# Altium schematic sheet 03_CAN.SchDoc
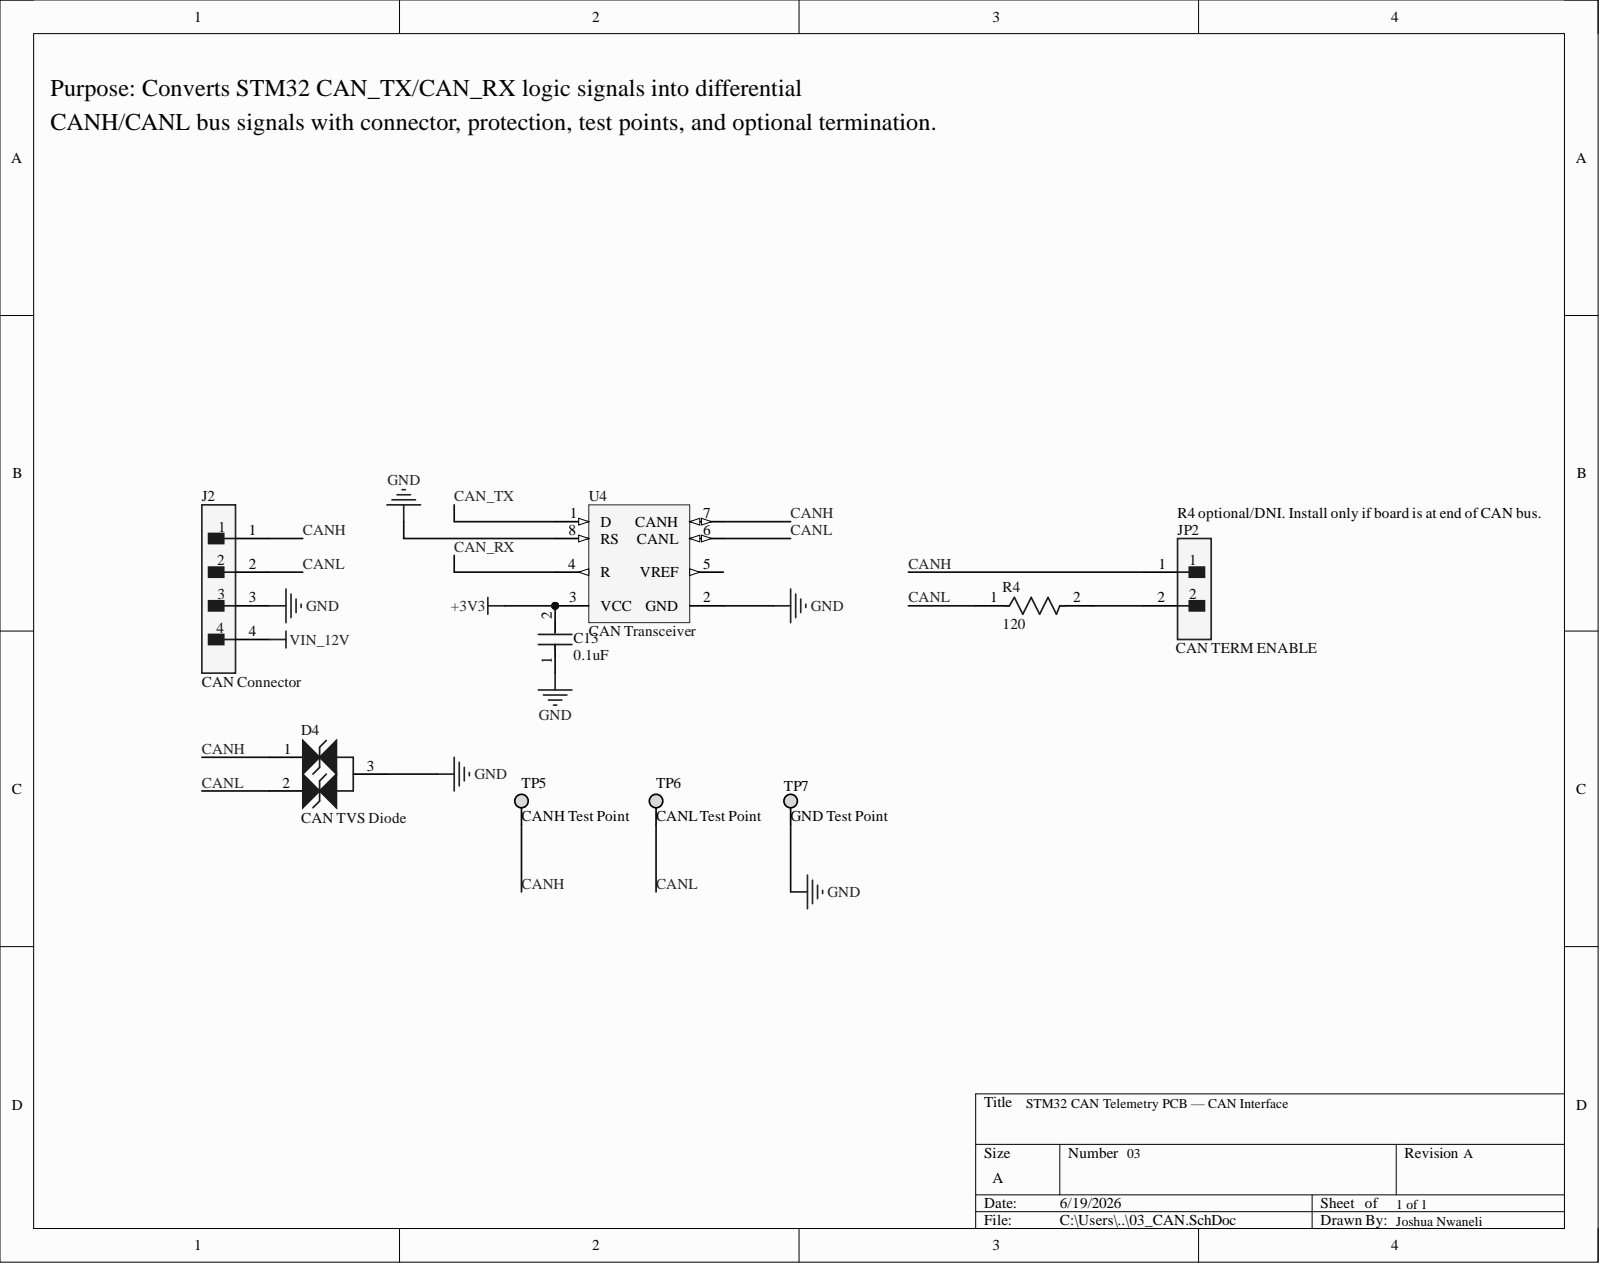
[diagram p0: 03_CAN.SchDoc]

## Parts
C13  0.1uF  [FP-CC0805-EB-MFG]
D4  CAN TVS Diode  [ONSC-SOT-23-3-318-08_V]
J2  CAN Connector  [FP-1777099-MFG]
JP2  CAN TERM ENABLE  [FP-TSW-102-12-T-S-MFG]
R4  120  [FP-RT0805-MFG]
TP5  CANH Test Point  [KSTN-5014_V]
TP6  CANL Test Point  [KSTN-5014_V]
TP7  GND Test Point  [KSTN-5014_V]
U4  CAN Transceiver  [D0008A_M]

## Nets
+3V3: C13.2 U4.3(VCC)
GND: C13.1 D4.3 J2.3 TP7.1 U4.2(GND) U4.8(RS)
VIN_12V: J2.4
CAN_RX: U4.4(R)
CAN_TX: U4.1(D)
CANH: D4.1 J2.1 JP2.1 TP5.1 U4.7(CANH)
CANL: D4.2 J2.2 R4.1 TP6.1 U4.6(CANL)
NetJP2_2: JP2.2 R4.2

Not connected: U4 5(VREF)

## Library data of the parts
C13 = CMP-00621-107850848-1: CC0805KKX7RYBB104 Capacitor Ceramic 0.01uF 250V X7R 10% 0805 T/R; Capacitance: 100nF; Case/Package: 0805; Component Kind: Standard; Height: 1.45mm; Library Name: Altium Content Vault; Material: Ceramic; Max Operating Temperature: 125°C; Min Operating Temperature: -55°C; NexarPartId: 107850848; Tolerance: 10%; Voltage Rating: 250V
D4 = CMP-1063-00169-1: Dual-line ESD/transient protection for CANH and CANL; Manufacturer: On Semiconductor; PartNumber: SZNUP2105LT3G; C Max (pF): 30; Direction: Bidirectional; IR Max (uA): 0.1; Number of Lines: 2; Packing: Tape and Reel; PPK Max (W): 350; V(BR) Min (V): 26.2; Component Kind: Standard; ComponentLink1Description: Manufacturer URL; ComponentLink1URL: http://www.onsemi.com/; ComponentLink2Description: Datasheet; ComponentLink2URL: http://www.onsemi.cn/pub_link/Collateral/NUP2105L-D.PDF; ComponentLink3Description: Package Specification; ComponentLink3URL: http://www.onsemi.cn/pub_link/Collateral/318-08.PDF; DatasheetVersion: Rev. 5; Library Name: Altium Content Vault; Mounting Technology: Surface Mount; PackageDescription: 3-Pin Small Outline Transistor, Body 2.92 x 1.3 mm, Pitch 0.95 mm; PackageReference: SOT-23-3-318-08; PackageVersion: Rev. AP, 11/2009; RoHS: Pb-Free
J2 = CMP-09399-000012-1: TERM BLOCK HDR 4POS VERT 5.08MM; Connector Type: Plug; Current: 12A; Number of Contacts: 4; Number of Levels: 1; Voltage: 300V; Voltage Rating: 250V; Color: Green; Component Kind: Standard; Contact Material: Tin; Contact Plating: Tin; Current Rating: 12A; Depth: 8.3mm; Flammability Rating: UL94 V-0; Height: 15.9mm; Housing Color: Green; Housing Material: Polybutylene; Lead Free: Lead Free; Length: 30.48mm; Library Name: Altium Content Vault; Max Current Rating: 12A; Mount: Through Hole; Number of Positions: 4; Number of Positions Per Level: 4; Number of Rows: 1; Orientation: Straight; Package Quantity: 50; Packaging: Bulk; Pitch: 5.08mm; Series: MSTBV; Termination: Solder; Weight: 5.467464oz; Width: 30.48mm
JP2 = CMP-02766-001444-1: Jumper to enable optional 120R CAN bus termination; Gender: Male; Number of Contacts: 2; Pitch: 2.54mm; Component Kind: Standard; Contact Material: Bronze; Contact Plating: Tin; Library Name: Altium Content Vault; Mount: Through Hole; Number of Rows: 1; Packaging: Bulk; Radiation Hardening: No; REACH SVHC: No SVHC; RoHS Compliant: Yes; Termination: Solder
R4 = CMP-03412-026588-1: RES SMD 120 OHM 0.5% 1/8W 0805; Manufacturer: Yageo; Manufacturer Part Number: RT0805DRD07120RL; Power Rating: 0.125W; Resistance: 120Ω; Temperature Coefficient: 25 ppm/°C; Tolerance: 0.01; Value: 120Ω; Voltage Rating: 150V; Automotive: No; Category: Res; Component Kind: Standard; ComponentLink2Description: Datasheet; ComponentLink2URL: http://www.yageo.com/documents/recent/PYu-RT_1-to-0.01_RoHS_L_9.pdf; Composition: Thin Film; Device Class L1: Passive Components; Device Class L2: Resistors; Device Class L3: Chip SMD Resistors; Digikey Description: RES SMD 120 OHM 0.5% 1/8W 0805; Footprint Url: http://www.yageo.com/exep/pages/download/literatures/PYu-R_Mount_10.pdf; Height: 0.6mm; Ipc Land Pattern Name: RESC200125X50; Lead Free: Yes; Library Name: Altium Content Vault; Octopart: https://octopart.com/rt0805drd07120rl-yageo-1204488; Package: RT0805; RoHS: TRUE; Series: RT; Temperature: -55°C to +155°C; Temperature Range High: +155°C; Temperature Range Low: -55°C
TP5-TP7 = CMP-1672-00006-4: Test Point, Yellow, Through Hole, RoHS, Bulk; Manufacturer: Keystone; Colour: Yellow; Component Kind: Standard; ComponentLink1Description: Manufacturer URL; ComponentLink1URL: http://www.keyelco.com/; ComponentLink2Description: Datasheet; ComponentLink2URL: http://www.keyelco.com/product-pdf.cfm?p=1323; Contact Material: Bronze; Contact Plating: Silver; DatasheetVersion: Rev. F, 12/2013; Height: 8.12 mm; Library Name: Altium Content Vault; Mount: Through Hole; Mounting Technology: Through Hole; Package Quantity: 100; PackageDescription: 1-Pin Through Hole Device, Dia 3.17 mm; PackageReference: 5014; Packaging: Bulk; REACH SVHC: No SVHC; RoHSCompliant: true
U4 = CMP-0315-00046-3: CAN Bus Transceiver, 3.3 V, -40 to 85 degC, 8-Pin SOIC (D), Green (RoHS & no Sb/Br), Tube; Generic Part Number: SN65HVD230; PartNumber: SN65HVD230D; Supply Voltage Max: 3.6 V; Supply Voltage Min: 3 V; Component Kind: Standard; ComponentLink1Description: Manufacturer URL; ComponentLink1URL: http://www.ti.com/; ComponentLink2Description: Datasheet; ComponentLink2URL: http://www.ti.com/general/docs/lit/getliterature.tsp?genericGeneric Part Number=SN65HVD230&fileType=pdf; DatasheetVersion: SLOS346N; Library Name: Altium Content Vault; Mounting Technology: Surface Mount; PackageDescription: 8-Pin Plastic Small Outline, Body 4.9 x 3.9 mm, Pitch 1.27 mm; Packing: Tube; RoHS: TRUE; Temperature Max: 85 degC; Temperature Min: -40 degC
